# Altium schematic sheet electronic_stopwatch.SchDoc(electronic_stopwatch)
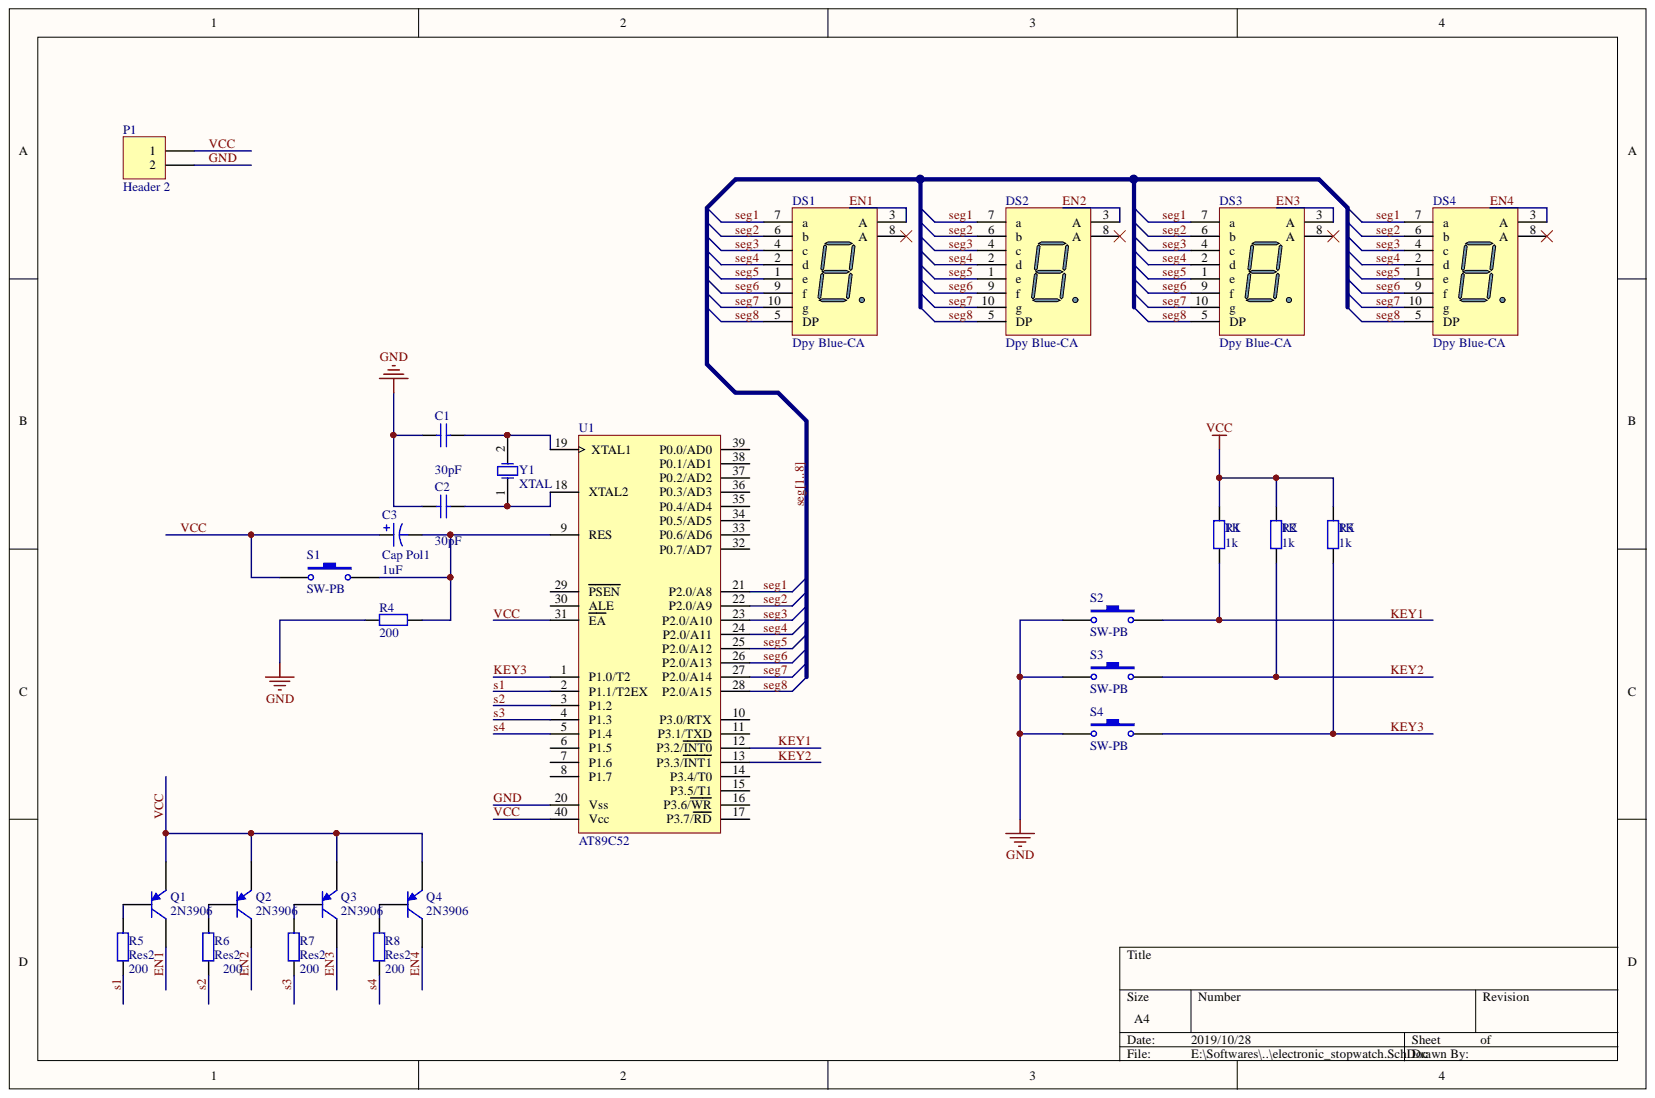
[diagram p0: electronic_stopwatch.SchDoc]

## Parts
C1  value 30pF  [RAD0.1]  (hidden comment: Cap)
C2  value 30pF  [RAD0.1]  (hidden comment: Cap)
C3  Cap Pol1  value 1uF  [RB.2/.4]
DS1  Dpy Blue-CA  [H]
DS2  Dpy Blue-CA  [H]
DS3  Dpy Blue-CA  [H]
DS4  Dpy Blue-CA  [H]
P1  Header 2  [HDR1X2]
Q1  2N3906  [TO-92A]
Q2  2N3906  [TO-92A]
Q3  2N3906  [TO-92A]
Q4  2N3906  [TO-92A]
R1  1K  [AXIAL-0.4]
R2  1K  [AXIAL-0.4]
R3  1K  [AXIAL-0.4]
R4  value 200  [AXIAL0.3]
R5  Res2  value 200  [AXIAL0.3]
R6  Res2  value 200  [AXIAL0.3]
R7  Res2  value 200  [AXIAL0.3]
R8  Res2  value 200  [AXIAL0.3]
S1  SW-PB  [SPST-2]
S2  SW-PB  [SPST-2]
S3  SW-PB  [SPST-2]
S4  SW-PB  [SPST-2]
U1  AT89C52  [DIP40]
Y1  XTAL  [R38]

## Nets
EN1: DS1.3(A) Q1.3
EN2: DS2.3(A) Q2.3
EN3: DS3.3(A) Q3.3
EN4: DS4.3(A) Q4.3
GND: C1.1 C2.1 P1.2 R4.1 S2.1 S3.1 S4.1 U1.20(Vss)
KEY1: R1.2 S2.2 U1.12(P3.2/INT0)
KEY2: R2.2 S3.2 U1.13(P3.3/INT1)
KEY3: R3.2 S4.2 U1.1(P1.0/T2)
s1: R5.1 U1.2(P1.1/T2EX)
s2: R6.1 U1.3(P1.2)
s3: R7.1 U1.4(P1.3)
s4: R8.1 U1.5(P1.4)
seg1: DS1.7(a) DS2.7(a) DS3.7(a) DS4.7(a) U1.21(P2.0/A8)  [also labelled SEG]
seg2: DS1.6(b) DS2.6(b) DS3.6(b) DS4.6(b) U1.22(P2.0/A9)  [also labelled SEG]
seg3: DS1.4(c) DS2.4(c) DS3.4(c) DS4.4(c) U1.23(P2.0/A10)  [also labelled SEG]
seg4: DS1.2(d) DS2.2(d) DS3.2(d) DS4.2(d) U1.24(P2.0/A11)  [also labelled SEG]
seg5: DS1.1(e) DS2.1(e) DS3.1(e) DS4.1(e) U1.25(P2.0/A12)  [also labelled SEG]
seg6: DS1.9(f) DS2.9(f) DS3.9(f) DS4.9(f) U1.26(P2.0/A13)  [also labelled SEG]
seg7: DS1.10(g) DS2.10(g) DS3.10(g) DS4.10(g) U1.27(P2.0/A14)  [also labelled SEG]
seg8: DS1.5(DP) DS2.5(DP) DS3.5(DP) DS4.5(DP) U1.28(P2.0/A15)  [also labelled SEG]
VCC: C3.1 P1.1 Q1.1 Q2.1 Q3.1 Q4.1 R1.1 R2.1 R3.1 S1.1 U1.31(EA) U1.40(Vcc)
NetC1_2: C1.2 U1.19(XTAL1) Y1.2
NetC2_2: C2.2 U1.18(XTAL2) Y1.1
NetC3_2: C3.2 R4.2 S1.2 U1.9(RES)
NetQ1_2: Q1.2 R5.2
NetQ2_2: Q2.2 R6.2
NetQ3_2: Q3.2 R7.2
NetQ4_2: Q4.2 R8.2

Not connected: DS1 8(A); DS2 8(A); DS3 8(A); DS4 8(A); U1 6(P1.5) 7(P1.6) 8(P1.7) 10(P3.0/RTX) 11(P3.1/TXD) 14(P3.4/T0) 15(P3.5/T1) 16(P3.6/WR) 17(P3.7/RD) 29(PSEN) 30(ALE) 32(P0.7/AD7) 33(P0.6/AD6) 34(P0.5/AD5) 35(P0.4/AD4) 36(P0.3/AD3) 37(P0.2/AD2) 38(P0.1/AD1) 39(P0.0/AD0)

## Library data of the parts
C1 = Cap: Capacitor; Value: 30pF; Simulation: CAP; Signal Integrity: Cap; Published: 8-Jun-2000; PackageReference: RAD-0.3; Library Name: Miscellaneous Devices.IntLib; LatestRevisionNote: Re-released for DXP Platform.; LatestRevisionDate: 17-Jul-2002; Component Kind: Standard; Publisher: Altium Limited
C2 = Cap: Capacitor; Simulation: CAP; Signal Integrity: Cap; Published: 8-Jun-2000; PackageReference: RAD-0.3; Library Name: Miscellaneous Devices.IntLib; LatestRevisionNote: Re-released for DXP Platform.; LatestRevisionDate: 17-Jul-2002; Component Kind: Standard; Publisher: Altium Limited
C3 = Cap Pol1: Polarized Capacitor (Radial); Value: 1uF; Simulation: CAP; Signal Integrity: Cap Pol; Published: 8-Jun-2000; PackageReference: RB7.6-15; Library Name: Miscellaneous Devices.IntLib; LatestRevisionNote: Re-released for DXP Platform.; LatestRevisionDate: 17-Jul-2002; Component Kind: Standard; Publisher: Altium Limited
DS1-DS4 = Dpy Blue-CA: 14.2 mm General Purpose Blue 7-Segment Display: CA, RH DP, Gray Surface; Note: IF = 10 mA; MinimumIntensity: 2020 ucd; Simulation: HDSP_501B; Published: 8-Jun-2000; PackageReference: H; PackageDocument: 24-Sep-2002; Library Name: Miscellaneous Devices.IntLib; LatestRevisionNote: Schematic revised, PCB Footprint replaced.; LatestRevisionDate: 17-Dec-2003; DatasheetDocument: 24-Sep-2002; ComponentLink1URL: http://www.agilent.com/; ComponentLink1Description: Manufacturer Link; Component Kind: Standard; Colour: Blue; Publisher: Altium Limited
P1 = Header 2: Header, 2-Pin; Signal Integrity: Connector; Library Name: Miscellaneous Connectors.IntLib; LatestRevisionNote: Re-released for DXP Platform.; LatestRevisionDate: 17-Jul-2002; Component Kind: Standard; Publisher: Altium Limited
Q1-Q4 = 2N3906: PNP General Purpose Amplifier; Simulation: 2N3906; Signal Integrity: 2N3906; Published: 8-Jun-2000; PackageReference: TO-92A; PackageDocument: Sep-1998; Library Name: Miscellaneous Devices.IntLib; LatestRevisionNote: PCB Footprint 'BCY-W3/D4.7' replaced; LatestRevisionDate: 15-Jan-2003; DatasheetDocument: 1997; ComponentLink2URL: http://www.fairchildsemi.com/ds/2N%2F2N3906.pdf; ComponentLink2Description: Datasheet; ComponentLink1URL: http://www.fairchildsemi.com/; ComponentLink1Description: Manufacturer Link; Component Kind: Standard; Code_JEDEC: TO-92A; Publisher: Altium Limited
R1-R8 = Res2: Resistor; Simulation: RESISTOR; Signal Integrity: Res; Published: 8-Jun-2000; PackageReference: AXIAL-0.4; Library Name: Miscellaneous Devices.IntLib; LatestRevisionNote: Re-released for DXP Platform.; LatestRevisionDate: 17-Jul-2002; Component Kind: Standard; Publisher: Altium Limited
  R1-R3: Value: 1k
S1-S4 = SW-PB: Switch; Published: 24-Mar-1999; PackageReference: SPST-2; Library Name: Miscellaneous Devices.IntLib; LatestRevisionNote: Re-released for DXP Platform.; LatestRevisionDate: 17-Jul-2002; Component Kind: Standard; Publisher: Altium Limited
Y1 = XTAL: Crystal Oscillator; Simulation: XTAL; Published: 8-Jun-2000; PackageReference: R38; Library Name: Miscellaneous Devices.IntLib; LatestRevisionNote: Re-released for DXP Platform.; LatestRevisionDate: 17-Jul-2002; Component Kind: Standard; Publisher: Altium Limited
U1 = AT89C52: Component Kind: Standard
